# Altium schematic sheet 250772_zener_diode_tester.SchDoc
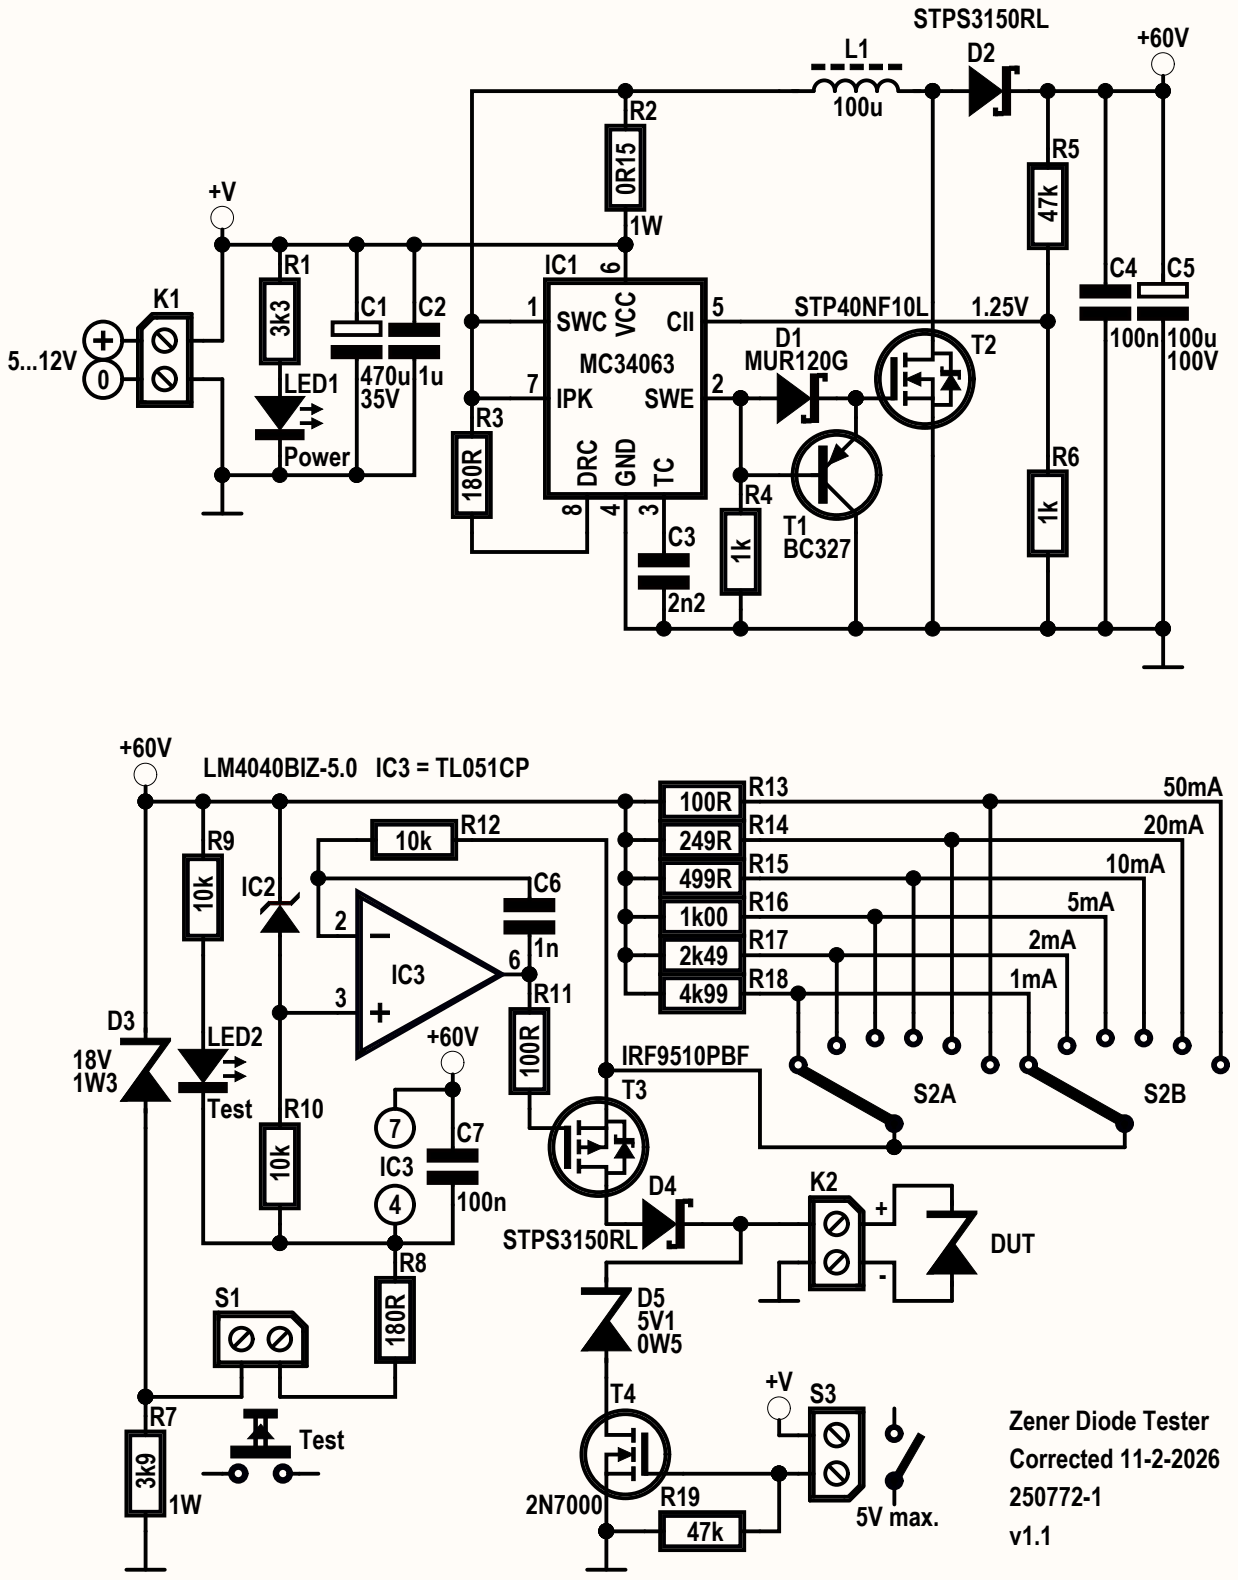
[diagram p0: 250772_zener_diode_tester.SchDoc]

## Parts
C1  TENSION: 35V  (hidden comment: 470u)
C2  1u
C3  2n2
C4  100n
C5  TENSION: 100V  (hidden comment: 100u)
C6  1n
C7  100n
D1  MUR120G
D2  STPS3150RL  (value not drawn)
D3  Voltage: 18V  Power: 1W3
D4  STPS3150RL
D5  Voltage: 5V1  Power: 0W5
IC1  MC34063
IC2  LM4040BIZ-5.0
IC3  TL051CP  (parts A,B)
K1  (no value)
K2  (no value)
L1  100u
LED1  (no value)
LED2  (no value)
PCB1
R1  3k3
R2  POWER: 1W  (hidden comment: 0R15)
R3  180R
R4  1k
R5  47k
R6  1k
R7  POWER: 1W  (hidden comment: 3k9)
R8  180R
R9  10k
R10  10k
R11  100R
R12  10k
R13  100R
R14  249R
R15  499R
R16  1k00
R17  2k49
R18  4k99
R19  47k
S1  (no value)
S2  2RSW6  (value not drawn)  (parts A,B)
S3  (no value)
T1  BC327
T2  STP40NF10L
T3  IRF9510PBF
T4  2N7000

## Nets
+60V: C4.1 C5.1 C7.1 D2.2 D3.2 IC2.2 IC3.7 R5.1 R9.1 R13.1 R14.1 R15.1 R16.1 R17.1 R18.1
+V: C1.1 C2.1 IC1.6(VCC) K1.1 R1.1 R2.2 S3.2
GND: C1.2 C2.2 C3.2 C4.2 C5.2 IC1.4(GND) K1.2 K2.2 LED1.2 R4.2 R6.2 R7.1 R19.2 T1.1 T2.1 T4.1
NetC3_1: C3.1 IC1.3(TC)
NetC6_1: C6.1 IC3.6 R11.1
NetC6_2: C6.2 IC3.2 R12.2
NetC7_2: C7.2 IC3.4 LED2.2 R8.2 R10.2
NetD1_1: D1.1 IC1.2(SWE) R4.1 T1.2
NetD1_2: D1.2 T1.3 T2.3
NetD2_1: D2.1 L1.2 T2.2
NetD3_1: D3.1 R7.2 S1.2
NetD4_1: D4.1 T3.2
NetD4_2: D4.2 D5.2 K2.1
NetD5_1: D5.1 T4.2
NetIC1_1: IC1.1(SWC) IC1.7(IPK) L1.1 R2.1 R3.2
NetIC1_5: IC1.5(CII) R5.2 R6.1
NetIC1_8: IC1.8(DRC) R3.1
NetIC2_1: IC2.1 IC3.3 R10.1
NetLED1_1: LED1.1 R1.2
NetLED2_1: LED2.1 R9.2
NetR8_1: R8.1 S1.1
NetR11_2: R11.2 T3.3
NetR12_1: R12.1 S2.13 S2.14 T3.1
NetR13_2: R13.2 S2.6 S2.12
NetR14_2: R14.2 S2.5 S2.11
NetR15_2: R15.2 S2.4 S2.10
NetR16_2: R16.2 S2.3 S2.9
NetR17_2: R17.2 S2.2 S2.8
NetR18_2: R18.2 S2.1 S2.7
NetR19_1: R19.1 S3.1 T4.3

## Library data of the parts
L1 = (no symbol name): Kemet: SBC8-101-242; VALUE: 100u; Farnell: 3291024
C1-C7 D1-D5 IC1-IC3 K1 K2 LED1 LED2 R1-R19 S1-S3 T1-T4 = (no symbol name)
  C1: VALUE: 470u
  C2: VALUE: 1u
  C3: VALUE: 2n2
  C4 C7: VALUE: 100n
  C5: VALUE: 100u
  C6: VALUE: 1n
  D1: STYLE: MUR120G; Farnell: 2101821
  D2 D4: STYLE: STPS3150RL; Farnell: 1702811
  IC2: VALUE: LM4040BIZ-5.0
  R1: VALUE: 3k3
  R2: VALUE: 0R15
  R3 R8: VALUE: 180R
  R4 R6: VALUE: 1k
  R5 R19: VALUE: 47k
  R7: VALUE: 3k9
  R9 R10 R12: VALUE: 10k
  R11 R13: VALUE: 100R
  R14: VALUE: 249R
  R15: VALUE: 499R
  R16: VALUE: 1k00
  R17: VALUE: 2k49
  R18: VALUE: 4k99
  T1: STYLE: BC327
  T2: STYLE: STP40NF10L
  T3: STYLE: IRF9510PBF; Farnell: 9103546
  T4: STYLE: 2N7000
